# Altium schematic sheet H-RS485.SchDoc(H-RS485)
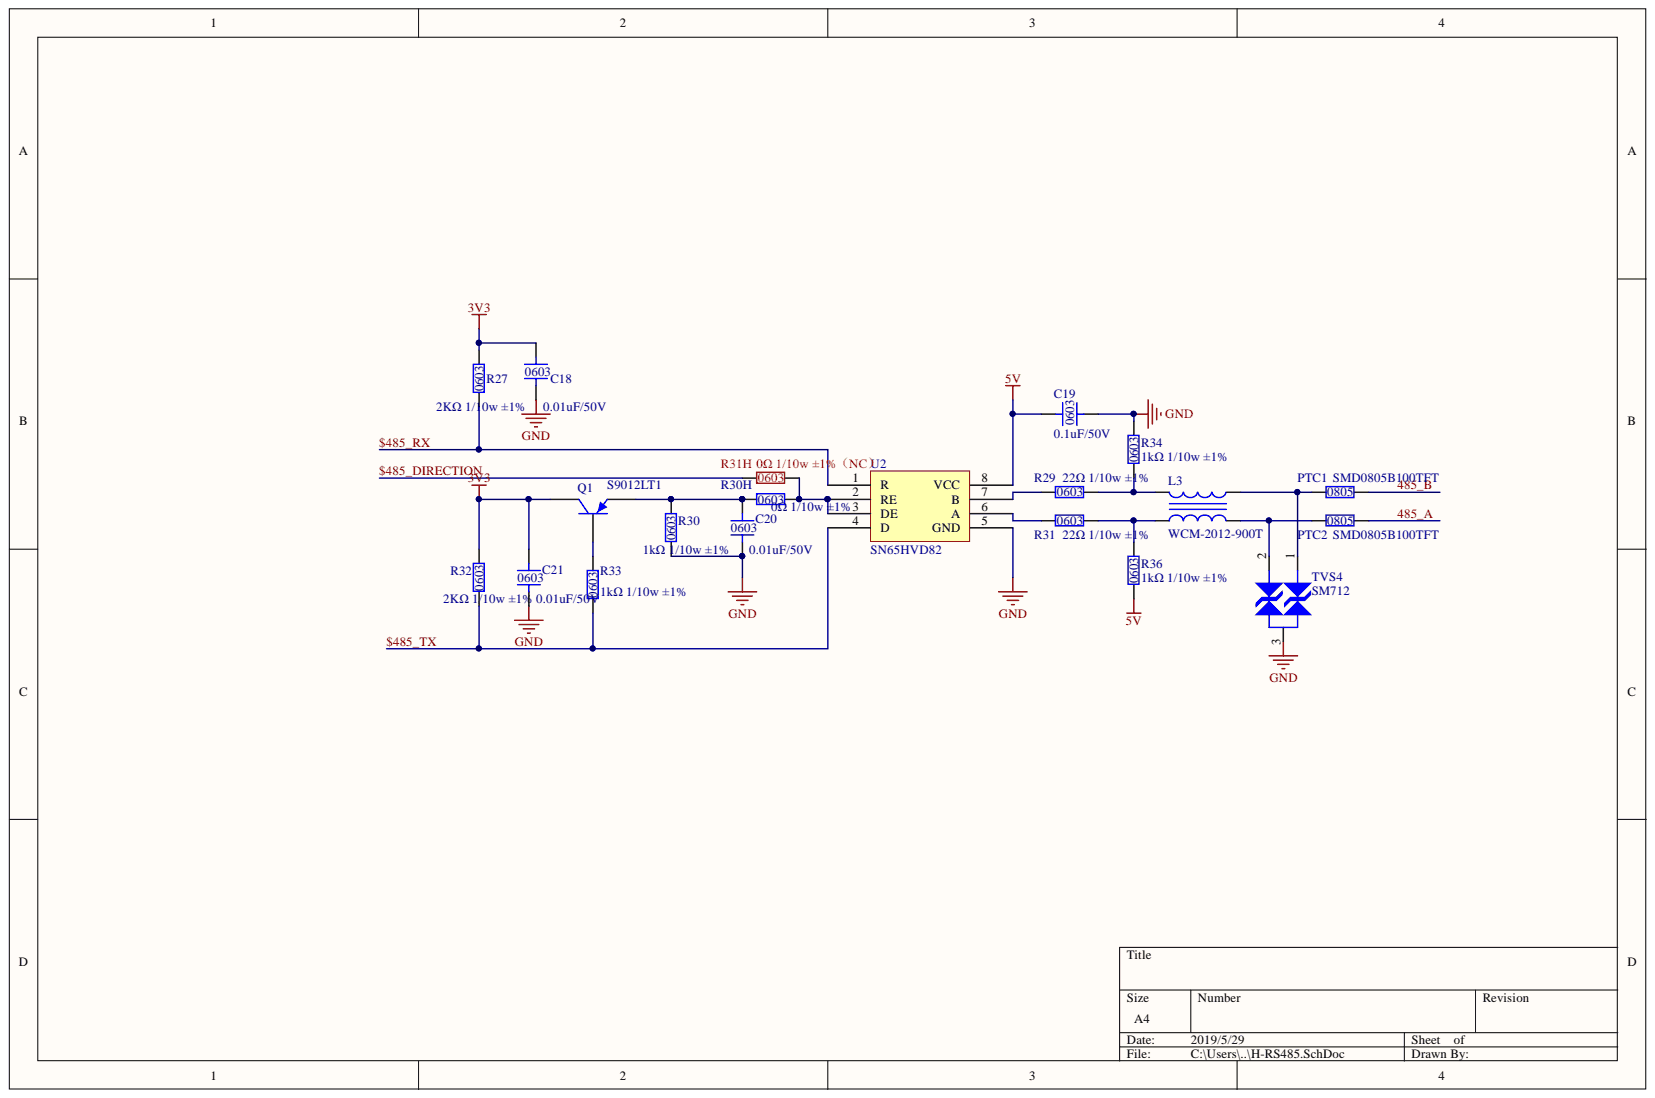
[diagram p0: H-RS485.SchDoc]

## Parts
C18  0.01uF/50V  [C-0603]
C19  0.1uF/50V  [C-0603]
C20  0.01uF/50V  [C-0603]
C21  0.01uF/50V  [C-0603]
L3  WCM-2012-900T  [贴片共模电感-2520]
PTC1  SMD0805B100TFT  [SMD-0805]
PTC2  SMD0805B100TFT  [SMD-0805]
Q1  S9012LT1  [SOT-23]
R27  2KΩ 1/10w ±1%  [R-0603]
R29  22Ω 1/10w ±1%  [R-0603]
R30  1kΩ 1/10w ±1%  [R-0603]
R30H  0Ω 1/10w ±1%  [R-0603]
R31  22Ω 1/10w ±1%  [R-0603]
R31H  0Ω 1/10w ±1%（NC）  [R-0603]
R32  2KΩ 1/10w ±1%  [R-0603]
R33  1kΩ 1/10w ±1%  [R-0603]
R34  1kΩ 1/10w ±1%  [R-0603]
R36  1kΩ 1/10w ±1%  [R-0603]
TVS4  SM712  [SOT-23]
U2  SN65HVD82  [SOP-8]

## Nets
3V3: C18.2 C21.2 Q1.3 R27.2 R32.2
5V: C19.2 R36.1 U2.8(VCC)
GND: C18.1 C19.1 C20.1 C21.1 R30.1 R34.2 TVS4.3 U2.5(GND)
485_A: PTC2.2
485_B: PTC1.2
$485_DIRECTION: R31H.1
$485_RX: R27.1 U2.1(R)
$485_TX: R32.1 R33.1 U2.4(D)
NetC20_2: C20.2 Q1.2 R30.2 R30H.1
NetL3_1: L3.1 R31.2 R36.2
NetL3_2: L3.2 R29.2 R34.1
NetL3_3: L3.3 PTC2.1 TVS4.2
NetL3_4: L3.4 PTC1.1 TVS4.1
NetQ1_1: Q1.1 R33.2
NetR29_1: R29.1 U2.7(B)
NetR30H_2: R30H.2 R31H.2 U2.2(RE) U2.3(DE)
NetR31_1: R31.1 U2.6(A)

## Library data of the parts
C18-C21 = 10uF/50V: Component Kind: Standard; Library Name: dahuan.SchLib
L3 = INDUCTOR C: Component Kind: Standard; Library Name: DH-SchLib.SchLib
PTC1 PTC2 = 3kΩ 1/10w ±1%: Component Kind: Standard; Library Name: dahuan.SchLib
Q1 = PNP: Component Kind: Standard; Library Name: dahuan.SchLib
R29 R30 R30H R31 R31H R33 R34 R36 = 2kΩ 1/10w ±1%: Component Kind: Standard; Library Name: dahuan.SchLib
TVS4 = ESD_DUAL: Component Kind: Standard; Library Name: dahuan.SchLib
U2 = SN65HVD72: Component Kind: Standard; Library Name: DH-SchLib.SchLib
R27 R32 = 2kΩ 1/10w ±1%: Component Kind: Standard; Library Name: dahuan.SchLib
